# Altium schematic sheet Conn Part.SchDoc("Conn Part")
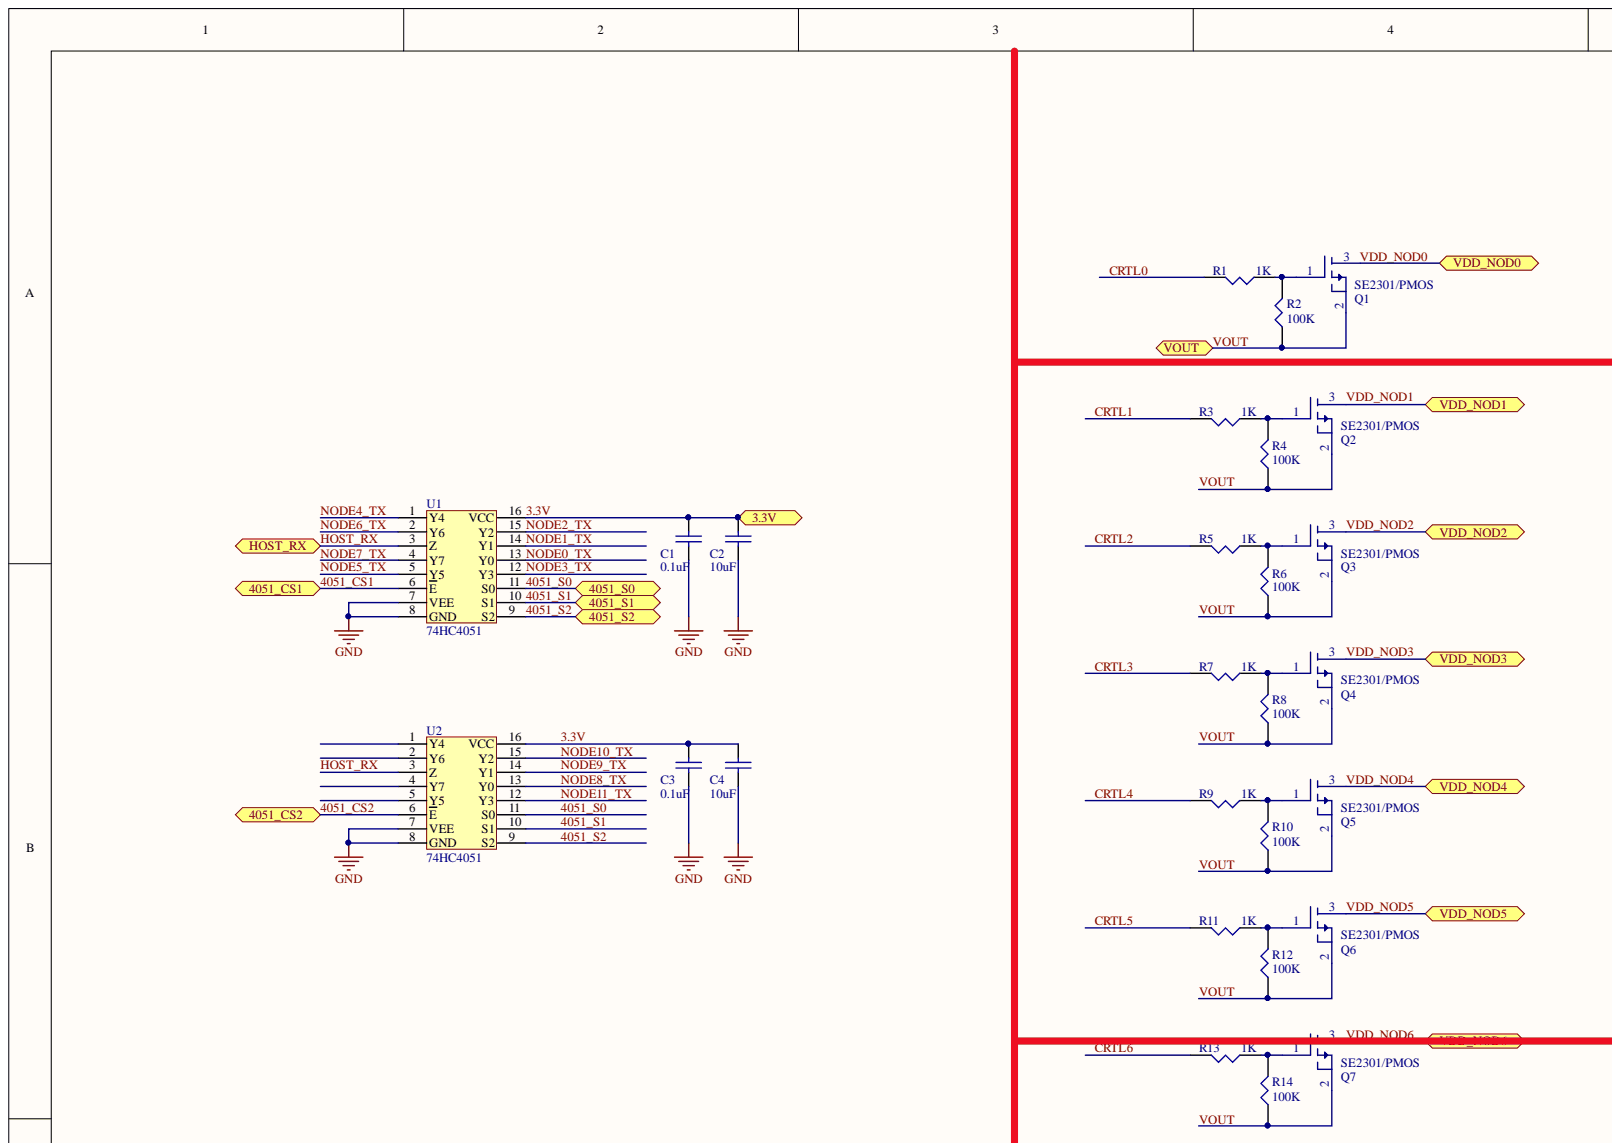
[diagram p0: Conn Part.SchDoc, top left]
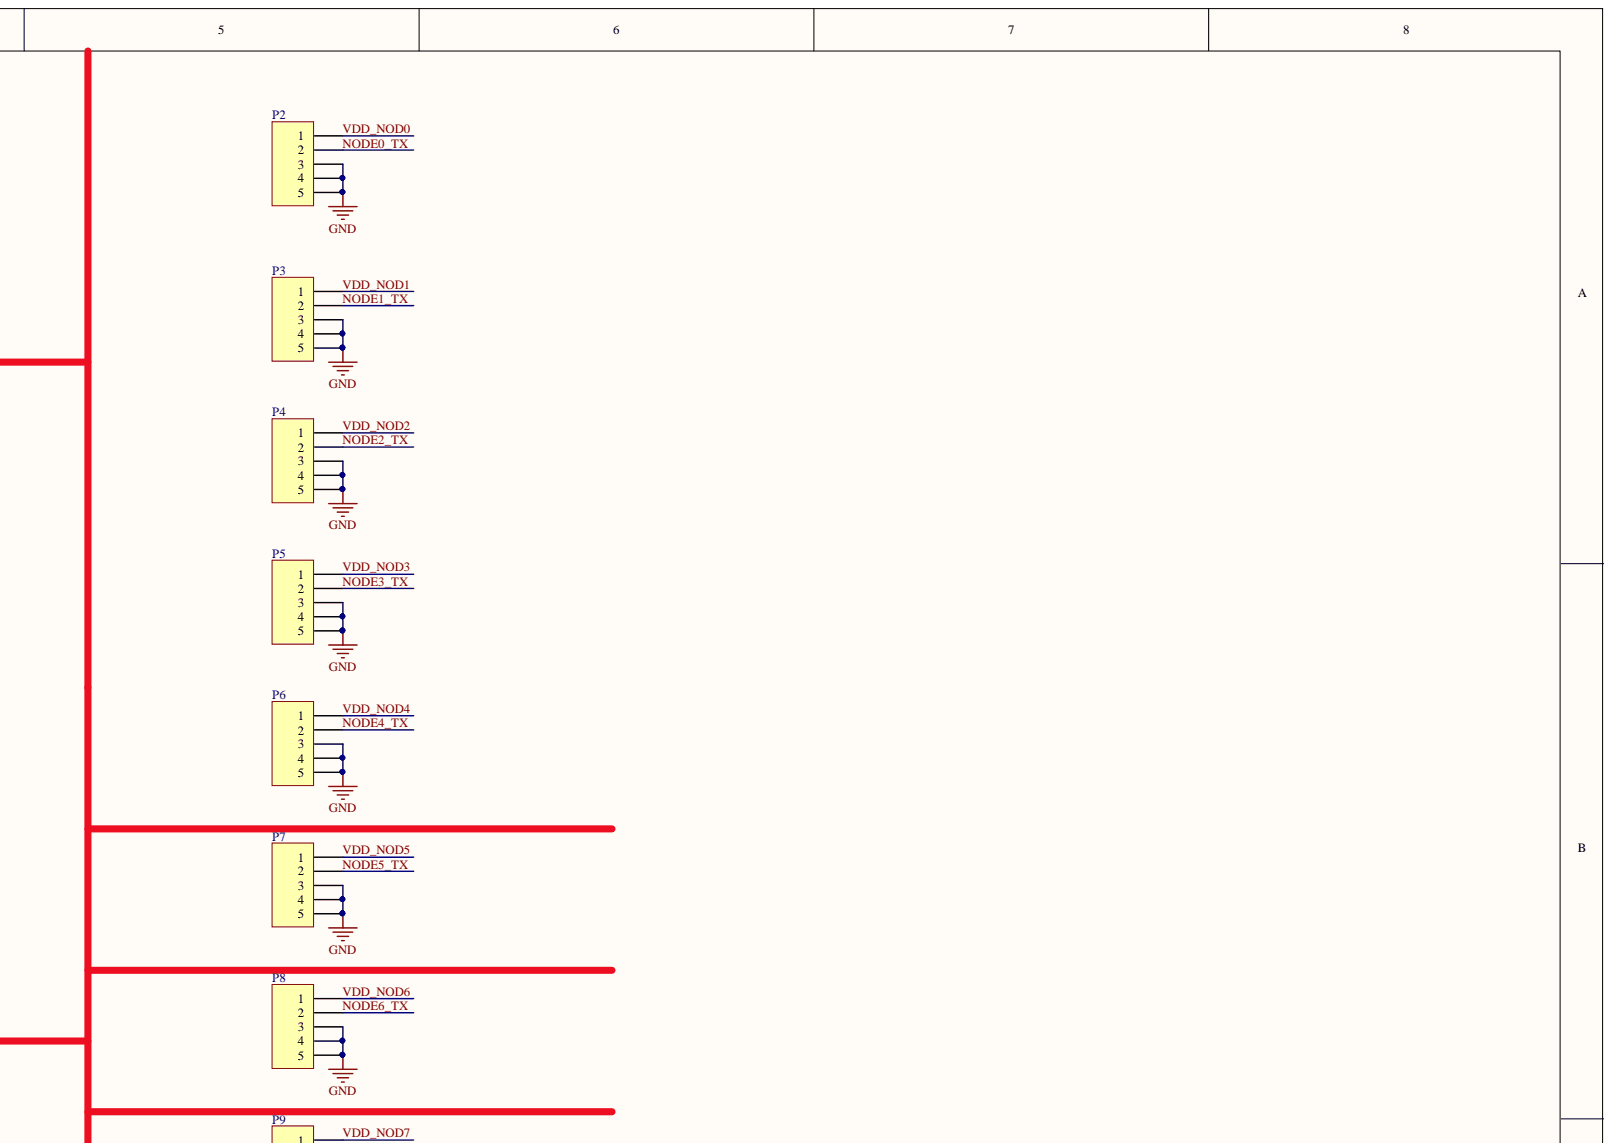
[diagram p1: Conn Part.SchDoc, top right]
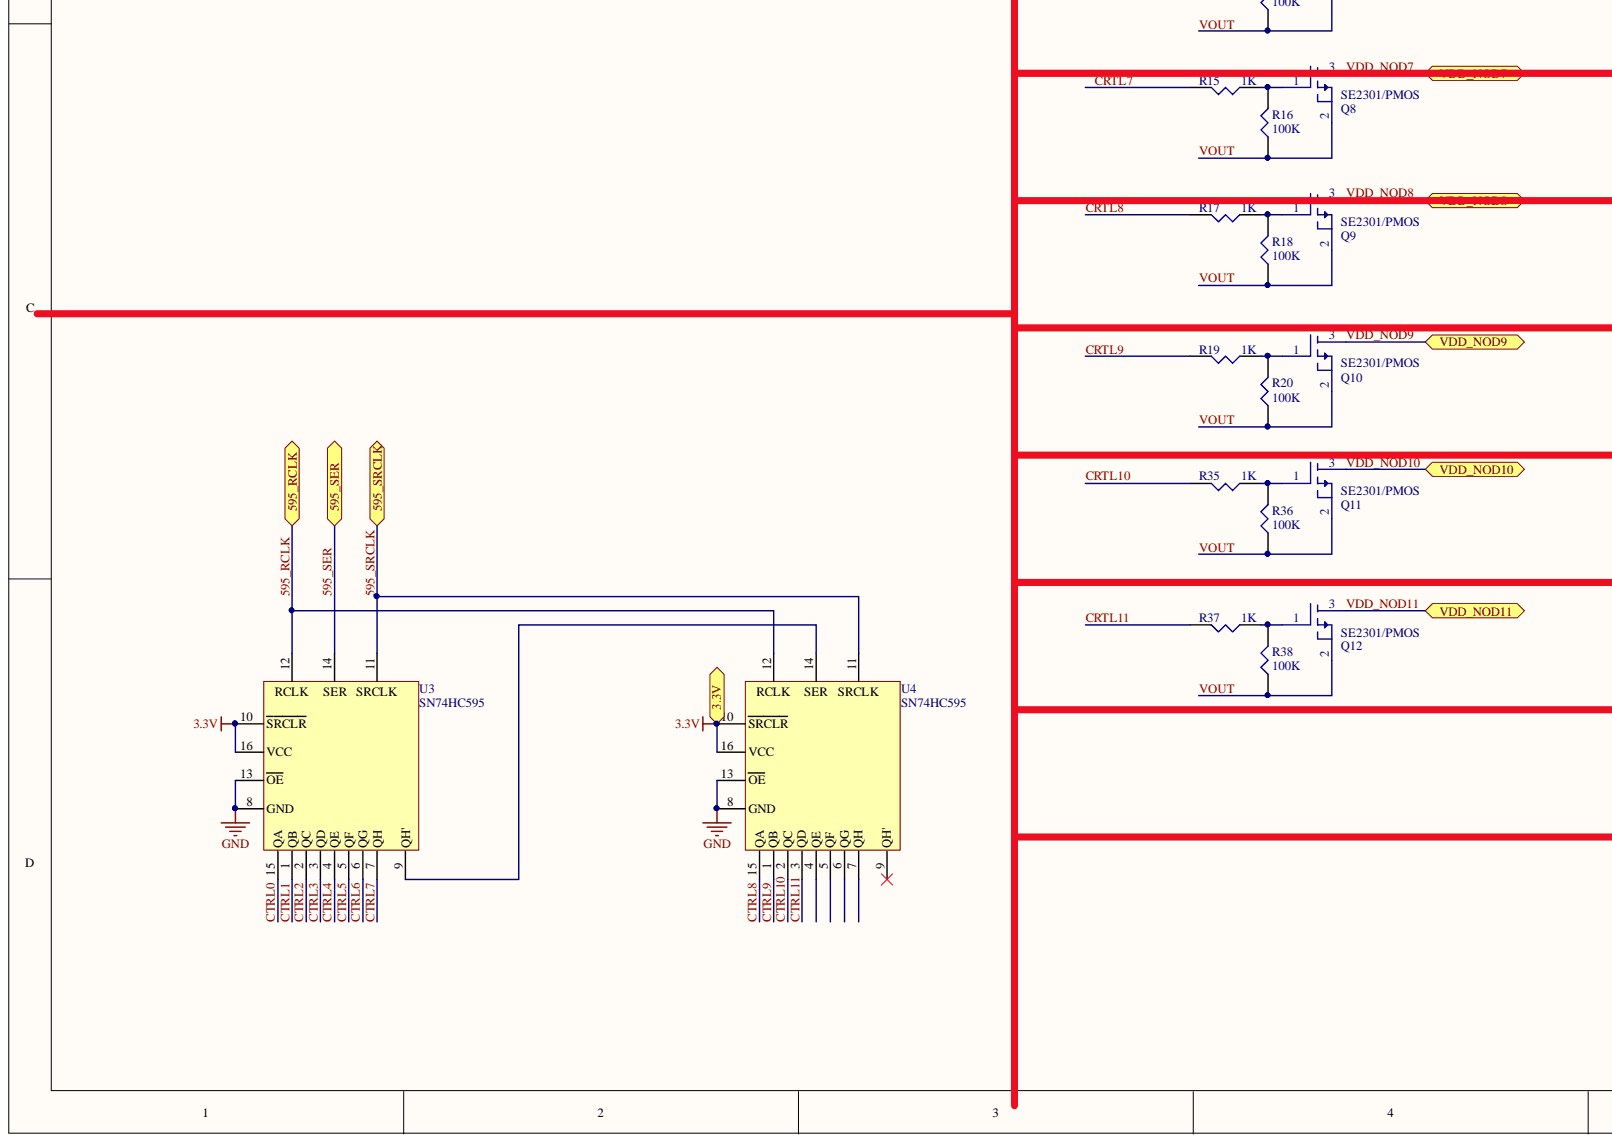
[diagram p2: Conn Part.SchDoc, bottom left]
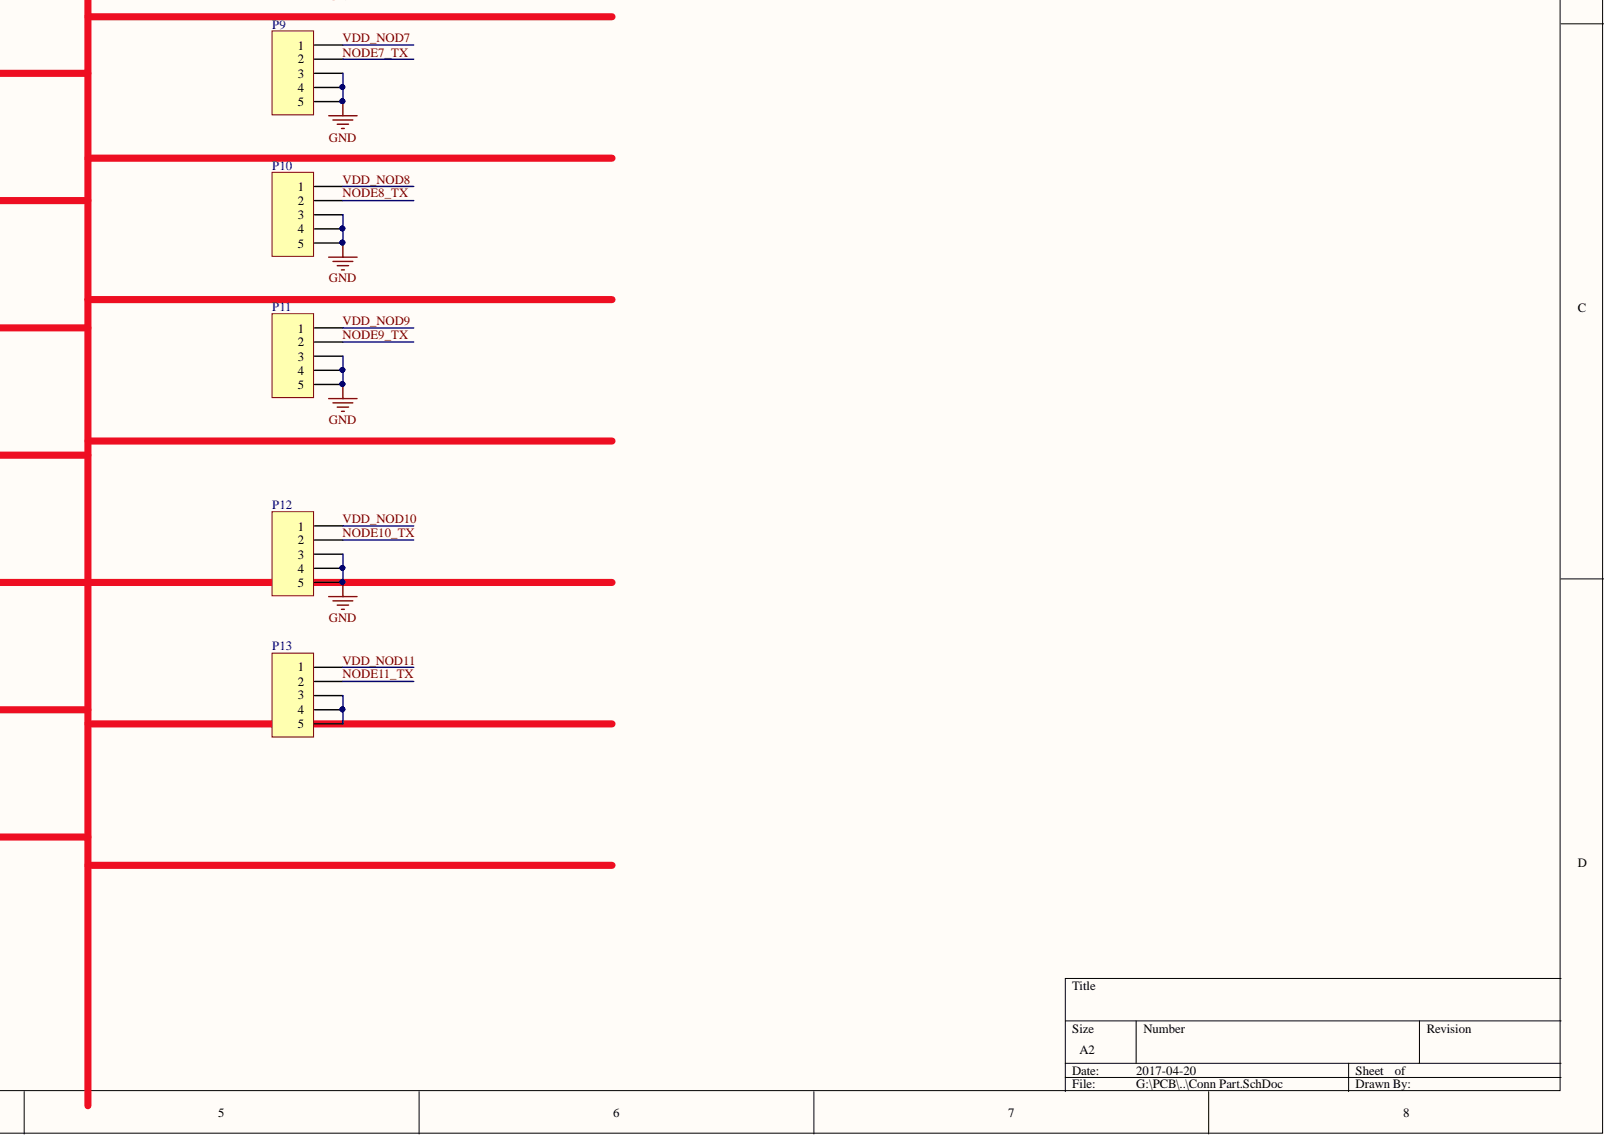
[diagram p3: Conn Part.SchDoc, bottom right]

## Parts
C1  0.1uF  [0402C-S]
C2  10uF  [0603C-S]
C3  0.1uF  [0402C-S]
C4  10uF  [0603C-S]
P2  Header 5  [1.0 Con x 3]  (value not drawn)
P3  Header 5  [1.0 Con x 3]  (value not drawn)
P4  Header 5  [1.0 Con x 3]  (value not drawn)
P5  Header 5  [1.0 Con x 3]  (value not drawn)
P6  Header 5  [1.0 Con x 3]  (value not drawn)
P7  Header 5  [1.0 Con x 3]  (value not drawn)
P8  Header 5  [1.0 Con x 3]  (value not drawn)
P9  Header 5  [1.0 Con x 3]  (value not drawn)
P10  Header 5  [1.0 Con x 3]  (value not drawn)
P11  Header 5  [1.0 Con x 3]  (value not drawn)
P12  Header 5  [1.0 Con x 3]  (value not drawn)
P13  Header 5  [1.0 Con x 3]  (value not drawn)
Q1  SE2301/PMOS  [TSMT3]
Q2  SE2301/PMOS  [TSMT3]
Q3  SE2301/PMOS  [TSMT3]
Q4  SE2301/PMOS  [TSMT3]
Q5  SE2301/PMOS  [TSMT3]
Q6  SE2301/PMOS  [TSMT3]
Q7  SE2301/PMOS  [TSMT3]
Q8  SE2301/PMOS  [TSMT3]
Q9  SE2301/PMOS  [TSMT3]
Q10  SE2301/PMOS  [TSMT3]
Q11  SE2301/PMOS  [TSMT3]
Q12  SE2301/PMOS  [TSMT3]
R1  1K  [0402R-S]
R2  100K  [0402R-S]
R3  1K  [0402R-S]
R4  100K  [0402R-S]
R5  1K  [0402R-S]
R6  100K  [0402R-S]
R7  1K  [0402R-S]
R8  100K  [0402R-S]
R9  1K  [0402R-S]
R10  100K  [0402R-S]
R11  1K  [0402R-S]
R12  100K  [0402R-S]
R13  1K  [0402R-S]
R14  100K  [0402R-S]
R15  1K  [0402R-S]
R16  100K  [0402R-S]
R17  1K  [0402R-S]
R18  100K  [0402R-S]
R19  1K  [0402R-S]
R20  100K  [0402R-S]
R35  1K  [0402R-S]
R36  100K  [0402R-S]
R37  1K  [0402R-S]
R38  100K  [0402R-S]
U1  74HC4051  [SO-G16/Y1.9]
U2  74HC4051  [SO-G16/Y1.9]
U3  SN74HC595
U4  SN74HC595

## Ports
3.3V, 595_RCLK, 595_SER, 595_SRCLK, 4051_CS1, 4051_CS2, 4051_S0, 4051_S1, 4051_S2, HOST_RX, VDD_NOD0, VDD_NOD1, VDD_NOD2, VDD_NOD3, VDD_NOD4, VDD_NOD5, VDD_NOD6, VDD_NOD7, VDD_NOD8, VDD_NOD9, VDD_NOD10, VDD_NOD11, VOUT

## Nets
GND: C1.2 C2.2 C3.2 C4.2 P2.3 P2.4 P2.5 P3.3 P3.4 P3.5 P4.3 P4.4 P4.5 P5.3 P5.4 P5.5 P6.3 P6.4 P6.5 P7.3 P7.4 P7.5 P8.3 P8.4 P8.5 P9.3 P9.4 P9.5 P10.3 P10.4 P10.5 P11.3 P11.4 P11.5 P12.3 P12.4 P12.5 U1.7(VEE) U1.8(GND) U2.7(VEE) U2.8(GND) U3.8(GND) U3.13(OE) U4.8(GND) U4.13(OE)
3.3V: C1.1 C2.1 C3.1 C4.1 U1.16(VCC) U2.16(VCC) U3.10(SRCLR) U3.16(VCC) U4.10(SRCLR) U4.16(VCC) ; port 3.3V
595_RCLK: U3.12 U4.12 ; port 595_RCLK
595_SER: U3.14 ; port 595_SER
595_SRCLK: U3.11 U4.11 ; port 595_SRCLK
4051_CS1: U1.6(E) ; port 4051_CS1
4051_CS2: U2.6(E) ; port 4051_CS2
4051_S0: U1.11(S0) U2.11(S0) ; port 4051_S0
4051_S1: U1.10(S1) U2.10(S1) ; port 4051_S1
4051_S2: U1.9(S2) U2.9(S2) ; port 4051_S2
CRTL0: R1.2
CRTL1: R3.2
CRTL2: R5.2
CRTL3: R7.2
CRTL4: R9.2
CRTL5: R11.2
CRTL6: R13.2
CRTL7: R15.2
CRTL8: R17.2
CRTL9: R19.2
CRTL10: R35.2
CRTL11: R37.2
CTRL0: U3.15(QA)
CTRL1: U3.1(QB)
CTRL2: U3.2(QC)
CTRL3: U3.3(QD)
CTRL4: U3.4(QE)
CTRL5: U3.5(QF)
CTRL6: U3.6(QG)
CTRL7: U3.7(QH)
CTRL8: U4.15(QA)
CTRL9: U4.1(QB)
CTRL10: U4.2(QC)
CTRL11: U4.3(QD)
HOST_RX: U1.3(Z) U2.3(Z) ; port HOST_RX
NODE0_TX: P2.2 U1.13(Y0)
NODE1_TX: P3.2 U1.14(Y1)
NODE2_TX: P4.2 U1.15(Y2)
NODE3_TX: P5.2 U1.12(Y3)
NODE4_TX: P6.2 U1.1(Y4)
NODE5_TX: P7.2 U1.5(Y5)
NODE6_TX: P8.2 U1.2(Y6)
NODE7_TX: P9.2 U1.4(Y7)
NODE8_TX: P10.2 U2.13(Y0)
NODE9_TX: P11.2 U2.14(Y1)
NODE10_TX: P12.2 U2.15(Y2)
NODE11_TX: P13.2 U2.12(Y3)
VDD_NOD0: P2.1 Q1.3 ; port VDD_NOD0
VDD_NOD1: P3.1 Q2.3 ; port VDD_NOD1
VDD_NOD2: P4.1 Q3.3 ; port VDD_NOD2
VDD_NOD3: P5.1 Q4.3 ; port VDD_NOD3
VDD_NOD4: P6.1 Q5.3 ; port VDD_NOD4
VDD_NOD5: P7.1 Q6.3 ; port VDD_NOD5
VDD_NOD6: P8.1 Q7.3 ; port VDD_NOD6
VDD_NOD7: P9.1 Q8.3 ; port VDD_NOD7
VDD_NOD8: P10.1 Q9.3 ; port VDD_NOD8
VDD_NOD9: P11.1 Q10.3 ; port VDD_NOD9
VDD_NOD10: P12.1 Q11.3 ; port VDD_NOD10
VDD_NOD11: P13.1 Q12.3 ; port VDD_NOD11
VOUT: Q1.2 Q2.2 Q3.2 Q4.2 Q5.2 Q6.2 Q7.2 Q8.2 Q9.2 Q10.2 Q11.2 Q12.2 R2.1 R4.1 R6.1 R8.1 R10.1 R12.1 R14.1 R16.1 R18.1 R20.1 R36.1 R38.1 ; port VOUT
NetP13_3: P13.3 P13.4 P13.5
NetQ1_1: Q1.1 R1.1 R2.2
NetQ2_1: Q2.1 R3.1 R4.2
NetQ3_1: Q3.1 R5.1 R6.2
NetQ4_1: Q4.1 R7.1 R8.2
NetQ5_1: Q5.1 R9.1 R10.2
NetQ6_1: Q6.1 R11.1 R12.2
NetQ7_1: Q7.1 R13.1 R14.2
NetQ8_1: Q8.1 R15.1 R16.2
NetQ9_1: Q9.1 R17.1 R18.2
NetQ10_1: Q10.1 R19.1 R20.2
NetQ11_1: Q11.1 R35.1 R36.2
NetQ12_1: Q12.1 R37.1 R38.2
NetU3_9: U3.9(QH') U4.14

Not connected: U2 1(Y4) 2(Y6) 4(Y7) 5(Y5); U4 4(QE) 5(QF) 6(QG) 7(QH) 9(QH')

## Library data of the parts
C1-C4 = CAP: 0402; Component Kind: Standard; Library Name: MoJi LIB.SchLib
P2-P13 = Header 5: Header, 5-Pin; Component Kind: Standard; LatestRevisionDate: 17-Jul-2002; LatestRevisionNote: Re-released for DXP Platform.; Signal Integrity: Connector; Library Name: AirNut Library.SchLib; Publisher: Altium Limited
Q1-Q12 = PMOS: 厂家：ROHM，型号：RRR030P03TL; Component Kind: Standard
R1-R20 R35-R38 = RES: 0402; Component Kind: Standard; Library Name: Schlib1.SchLib
U1 U2 = 74HC4051: Component Kind: Standard; Library Name: AirNut Library.SchLib
U3 U4 = SN74HC595: Component Kind: Standard; Library Name: AirNut Library.SchLib
